# Altium schematic sheet 13 Expansion HDR.SchDoc
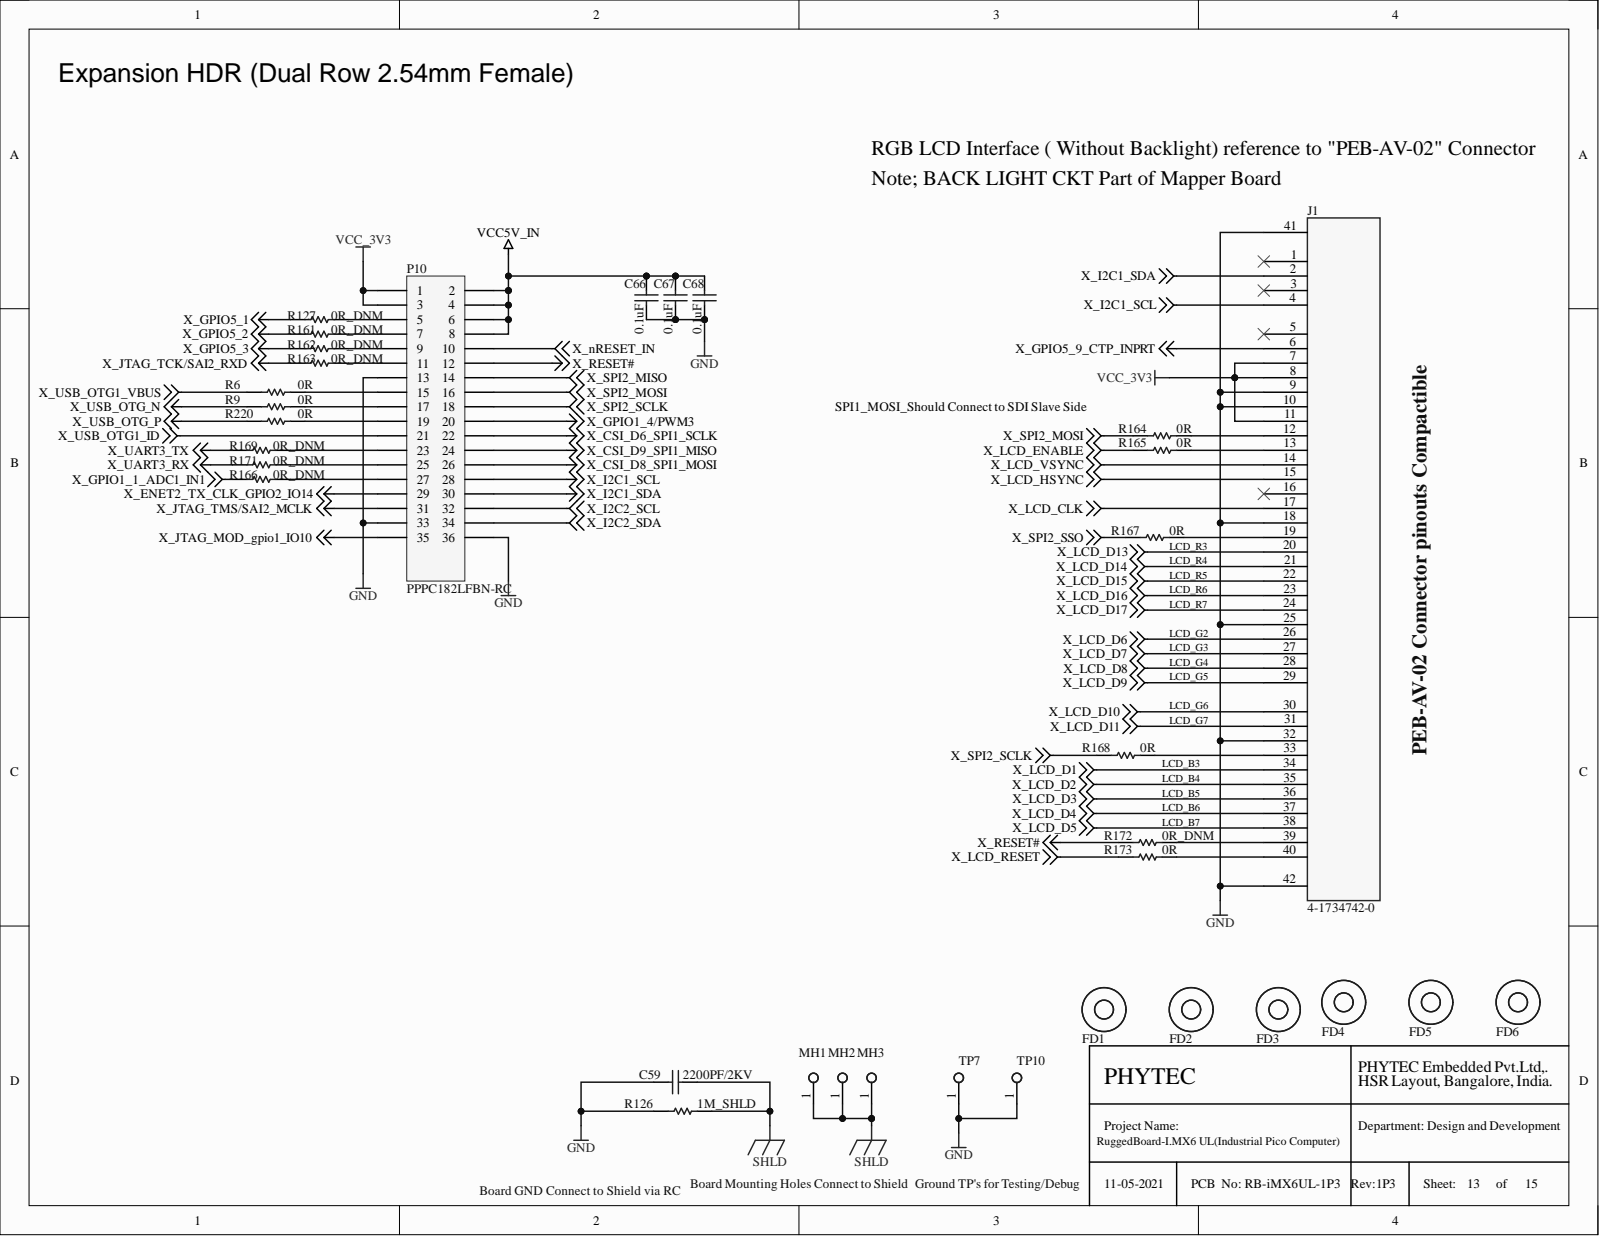
[diagram p0: 13 Expansion HDR.SchDoc]

## Parts
C59  2200PF/2KV  [C1210]
C66  0.1uF  [C0402]
C67  0.1uF  [C0402]
C68  0.1uF  [C0402]
FD1  FD  [Fiducial_1D_3D_1]  (no BOM)
FD2  FD  [Fiducial_1D_3D]  (no BOM)
FD3  FD  [Fiducial_1D_3D]  (no BOM)
FD4  FD  [Fiducial_1D_3D_1]  (no BOM)
FD5  FD  [Fiducial_1D_3D]  (no BOM)
FD6  FD  [Fiducial_1D_3D]  (no BOM)
J1  4-1734742-0  [LCD_CONN_0.5_40N_RA]
MH1  Test Point  [MH_3.2MM]  (value not drawn)  (no BOM)
MH2  Test Point  [MH_3.2MM]  (value not drawn)  (no BOM)
MH3  Test Point  [MH_3.2MM]  (value not drawn)  (no BOM)
P10  PPPC182LFBN-RC  [HEADER_254P_18X2N_FM]
R6  0R  [R0603]
R9  0R  [R0402]
R126  1M_SHLD  [R1210]
R127  0R_DNM  [R0402]
R161  0R_DNM  [R0402]
R162  0R_DNM  [R0402]
R163  0R_DNM  [R0402]
R164  0R  [R0402]
R165  0R  [R0402]
R166  0R_DNM  [R0402]
R167  0R  [R0402]
R168  0R  [R0402]
R169  0R_DNM  [R0402]
R171  0R_DNM  [R0402]
R172  0R_DNM  [R0402]
R173  0R  [R0402]
R220  0R  [R0402]
TP7  Test Point  [TEST POINT PC MINI .040"D YELLOW]  (value not drawn)
TP10  Test Point  [TEST POINT PC MINI .040"D YELLOW]  (value not drawn)

## Nets
GND: C59.2 C66.1 C67.1 C68.1 J1.9 J1.10 J1.18 J1.25 J1.32 J1.41 J1.42 P10.13 P10.33 P10.36 R126.1 TP7.1 TP10.1
SHLD: C59.1 MH1.1 MH2.1 MH3.1 R126.2
VCC5V_IN: C66.2 C67.2 C68.2 P10.2 P10.4 P10.6 P10.8
VCC_3V3: J1.7 J1.8 J1.11 P10.1 P10.3
X_CSI_D6_SPI1_SCLK: P10.22
X_CSI_D8_SPI1_MOSI: P10.26
X_CSI_D9_SPI1_MISO: P10.24
X_ENET2_TX_CLK_GPIO2_IO14: P10.29
X_GPIO1_1_ADC1_IN1: R166.2
X_GPIO1_4/PWM3: P10.20
X_GPIO5_1: R127.2
X_GPIO5_2: R161.2
X_GPIO5_3: R162.2
X_GPIO5_9_CTP_INPRT: J1.6
X_I2C1_SCL: J1.4 P10.28
X_I2C1_SDA: J1.2 P10.30
X_I2C2_SCL: P10.32
X_I2C2_SDA: P10.34
X_JTAG_MOD_gpio1_IO10: P10.35
X_JTAG_TCK/SAI2_RXD: R163.2
X_JTAG_TMS/SAI2_MCLK: P10.31
X_LCD_CLK: J1.17
X_LCD_D1: J1.34
X_LCD_D2: J1.35
X_LCD_D3: J1.36
X_LCD_D4: J1.37
X_LCD_D5: J1.38
X_LCD_D6: J1.26
X_LCD_D7: J1.27
X_LCD_D8: J1.28
X_LCD_D9: J1.29
X_LCD_D10: J1.30
X_LCD_D11: J1.31
X_LCD_D13: J1.20
X_LCD_D14: J1.21
X_LCD_D15: J1.22
X_LCD_D16: J1.23
X_LCD_D17: J1.24
X_LCD_ENABLE: R165.2
X_LCD_HSYNC: J1.15
X_LCD_RESET: R173.2
X_LCD_VSYNC: J1.14
X_nRESET_IN: P10.10
X_RESET#: P10.12 R172.2
X_SPI2_MISO: P10.14
X_SPI2_MOSI: P10.16 R164.2
X_SPI2_SCLK: P10.18 R168.2
X_SPI2_SSO: R167.2
X_UART3_RX: R171.2
X_UART3_TX: R169.2
X_USB_OTG1_ID: P10.21
X_USB_OTG1_VBUS: R6.1
X_USB_OTG_N: R9.1
X_USB_OTG_P: R220.1
NetJ1_12: J1.12 R164.1
NetJ1_13: J1.13 R165.1
NetJ1_19: J1.19 R167.1
NetJ1_33: J1.33 R168.1
NetJ1_39: J1.39 R172.1
NetJ1_40: J1.40 R173.1
NetP10_5: P10.5 R127.1
NetP10_7: P10.7 R161.1
NetP10_9: P10.9 R162.1
NetP10_11: P10.11 R163.1
NetP10_15: P10.15 R6.2
NetP10_17: P10.17 R9.2
NetP10_19: P10.19 R220.2
NetP10_23: P10.23 R169.1
NetP10_25: P10.25 R171.1
NetP10_27: P10.27 R166.1

Not connected: J1 1 3 5 16

## Library data of the parts
C59 = Cap: CAP CER 2200PF 2KV X7R 1210; ASSEMBLY_TYPE: SMD; MACRO: I.MX 6 SoM Connectors; MFG: Johanson Dielectrics Inc.; MFG_PN: 202S41W222KV4E; Component Kind: Standard; Library Name: Miscellaneous Devices.IntLib; PACKAGE: 1210; TEMPERATURE: -55°C ~ 125°C
C66-C68 = Cap: CAP CER 0.1UF 50V X7R 0402; ASSEMBLY_TYPE: SMT; MACRO: Mini PCIe CONN; MFG: Murata; MFG_PN: GRM155R71H104ME14D; Component Kind: Standard; Library Name: Miscellaneous Devices.IntLib; PACKAGE: 0402; Signal Integrity: Cap; Simulation: CAP
FD1-FD6 = FD: PCB Fiducial; Library Name: CHEMITO_RDSO_1.SCHLIB
J1 = CON40_2: CONN FFC VERT 40POS 0.50MM SMD; ASSEMBLY_TYPE: SMT; MFG: TE Connectivity AMP Connectors; Component Kind: Standard; Library Name: AT070TN83 RGB LCD ADAPTER.SchLib; MFG_PN: 4-1734742-0
MH1-MH3 = TP: TEST POINT PC MINI .040"D RED; ASSEMBLY_TYPE: TH; MFG_PN: MTG_HOLE; Library Name: TVS i,MX6 Mother Board.SCHLIB; PACKAGE: TH
P10 = Header 20X2: CONN HDR 36POS 0.1 GOLD PCB; MFG: Sullins Connector Solutions; Component Kind: Standard; Library Name: PICO_COMPUTER_IMX6ULL_Rev1P1.SCHLIB; MFG_PN: PPPC182LFBN-RC
R6 = Res1: RES SMD 0.0OHM JUMPER 1/16W 0603; ASSEMBLY_TYPE: SMT; MFG: YAGE0; MFG_PN: RC0603JR-070RL; Component Kind: Standard; Library Name: Miscellaneous Devices.IntLib; PACKAGE: 0603; Signal Integrity: Res; Simulation: RESISTOR
R9 R220 = Res1: RES SMD 0.0OHM JUMPER 1/16W 0402; ASSEMBLY_TYPE: SMT; MFG: YAGE0; MFG_PN: RC0402JR-070RL; Component Kind: Standard; Library Name: Miscellaneous Devices.IntLib; PACKAGE: 0402; Signal Integrity: Res; Simulation: RESISTOR
R126 = Res1: RES SMD 1M OHM 1% 1/2W 1210; ASSEMBLY_TYPE: SMT; KHK_AN: RM779; MACRO: I.MX 6 SoM Connectors; MFG: PEC; MFG_PN: ERJ-14NF1004U; Component Kind: Standard; Library Name: Miscellaneous Devices.IntLib; PACKAGE: 1210; TEMPERATURE: -55°C ~ 155°C
R127 R161-R163 R166 R169 R171 = Res1: RES 0 OHM JUMPER 1/16W 0402; ASSEMBLY_TYPE: SMT; MFG: Stackpole Electronics Inc.; MFG_PN: RMCF0402ZT0R00; Component Kind: Standard; Library Name: Miscellaneous Devices.IntLib; PACKAGE: 0402
R164 R165 R167 R168 R172 R173 = Res1: RES SMD 0.0OHM JUMPER 1/16W 0402; ASSEMBLY_TYPE: SMT; MFG: YAGEO; MFG_PN: RC0402JR-070RL; Component Kind: Standard; Library Name: Miscellaneous Devices.IntLib; PACKAGE: 0402; Signal Integrity: Res; Simulation: RESISTOR
TP7 TP10 = TP: TEST POINT PC MINI .040"D RED; ASSEMBLY_TYPE: TH; MACRO: Power Management (VYS, 5V, 3.3V and Reset); MFG: Keystone; MFG_PN: 5000; Component Kind: Standard; PACKAGE: Assembly; TEMPERATURE: -55°C ~ 155°C; Library Name: HTT SoM - Sept 7 2016 V1P2 .SCHLIB
